# Altium schematic sheet Digital.SchDoc
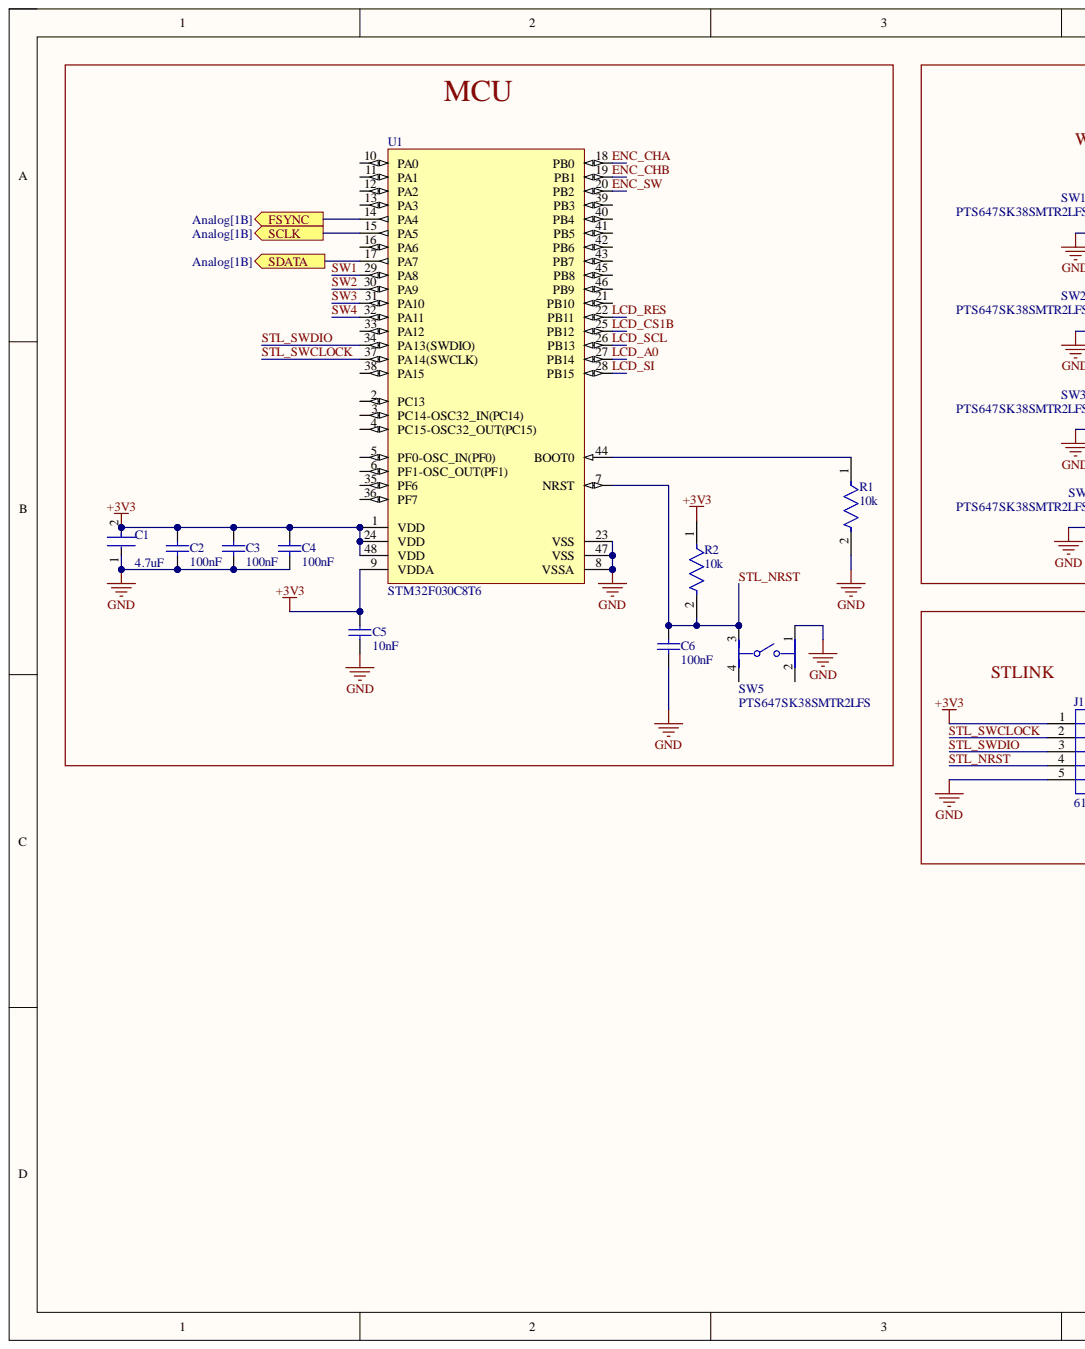
[diagram p0: Digital.SchDoc, left]
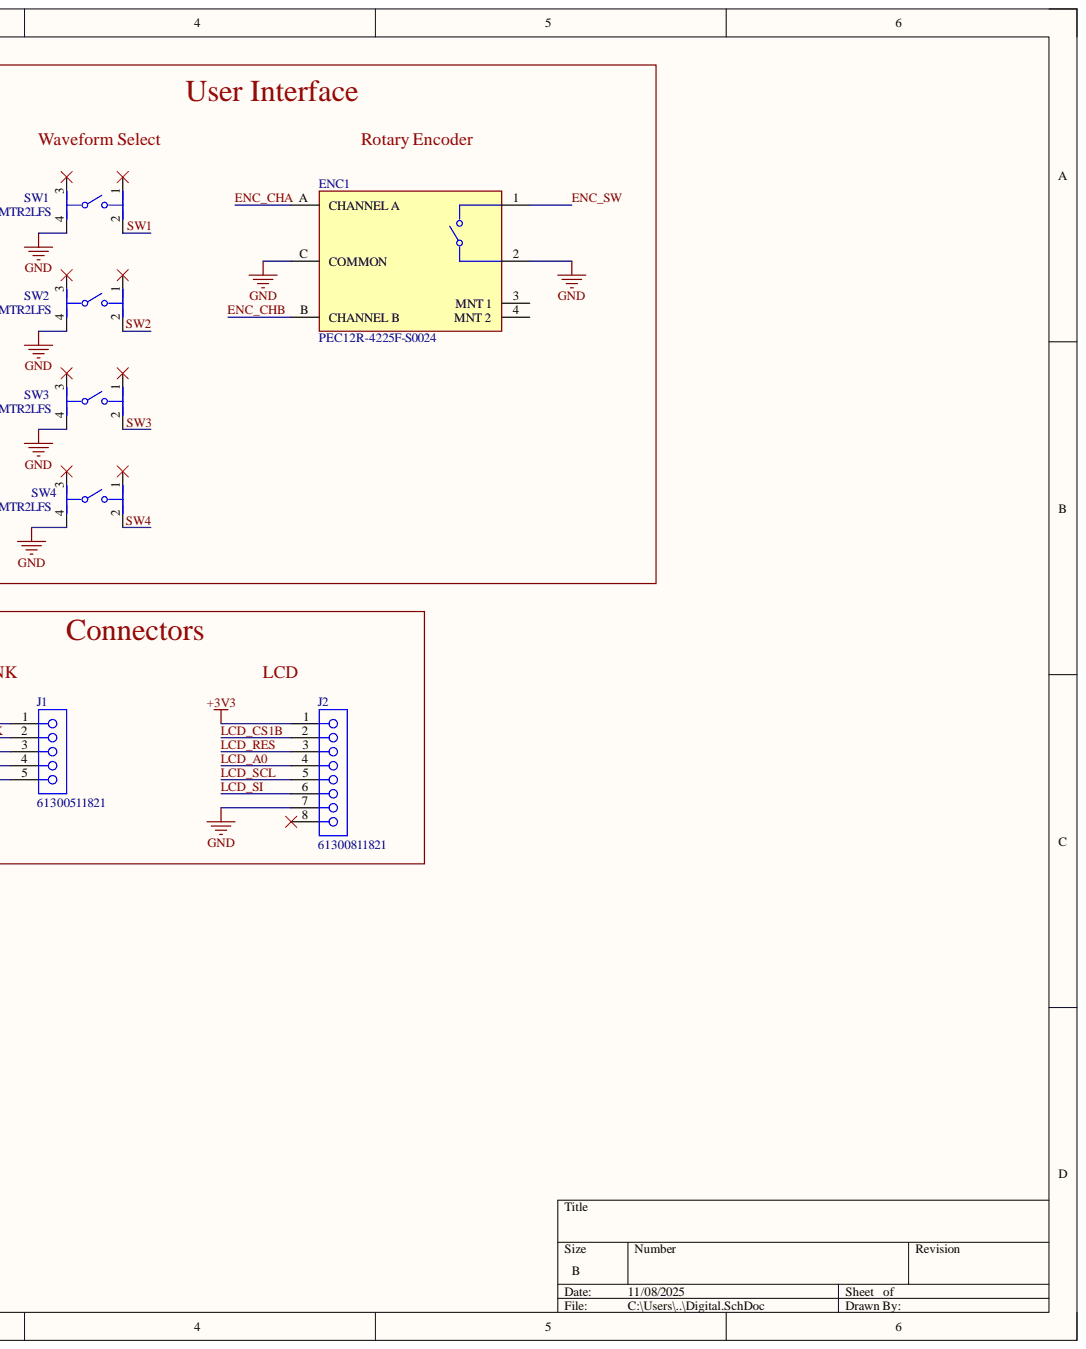
[diagram p1: Digital.SchDoc, right]

## Parts
C1  4.7uF  [FP-GRM219-0_15-0_1-IPC_B]
C2  100nF  [CAPC1608X87X45LL20T05]
C3  100nF  [CAPC1608X87X45LL20T05]
C4  100nF  [CAPC1608X87X45LL20T05]
C5  10nF  [CAPC1206(3216)150_L]
C6  100nF  [CAPC1608X87X45LL20T05]
ENC1  PEC12R-4225F-S0024  [FP-PEC12R-4225F-S0024-MFG]
J1  61300511821  [61300511821]
J2  61300811821  [61300811821]
R1  10k  [FP-TFCR0402-IPC_B]
R2  10k  [FP-TFCR0402-IPC_B]
SW1  PTS647SK38SMTR2LFS  [FP-PTS647SK38SMTR2LFS-MFG]
SW2  PTS647SK38SMTR2LFS  [FP-PTS647SK38SMTR2LFS-MFG]
SW3  PTS647SK38SMTR2LFS  [FP-PTS647SK38SMTR2LFS-MFG]
SW4  PTS647SK38SMTR2LFS  [FP-PTS647SK38SMTR2LFS-MFG]
SW5  PTS647SK38SMTR2LFS  [FP-PTS647SK38SMTR2LFS-MFG]
U1  STM32F030C8T6  [STM-LQFP48_M]

## Ports
FSYNC, SCLK, SDATA

## Nets
+3V3: C1.2 C2.2 C3.2 C4.2 C5.2 J1.1 J2.1 R2.1 U1.1(VDD) U1.9(VDDA) U1.24(VDD) U1.48(VDD)
GND: C1.1 C2.1 C3.1 C4.1 C5.1 C6.1 ENC1.2 ENC1.C(COMMON) J1.5 J2.7 R1.2 SW1.4 SW2.4 SW3.4 SW4.4 SW5.1 U1.8(VSSA) U1.23(VSS) U1.47(VSS)
ENC_CHA: ENC1.A(CHANNEL_A) U1.18(PB0)
ENC_CHB: ENC1.B(CHANNEL_B) U1.19(PB1)
ENC_SW: ENC1.1 U1.20(PB2)
FSYNC: U1.14(PA4) ; port FSYNC
LCD_A0: J2.4 U1.27(PB14)
LCD_CS1B: J2.2 U1.25(PB12)
LCD_RES: J2.3 U1.22(PB11)
LCD_SCL: J2.5 U1.26(PB13)
LCD_SI: J2.6 U1.28(PB15)
SCLK: U1.15(PA5) ; port SCLK
SDATA: U1.17(PA7) ; port SDATA
STL_NRST: C6.2 J1.4 R2.2 SW5.3 U1.7(NRST)
STL_SWCLOCK: J1.2 U1.37(PA14(SWCLK))
STL_SWDIO: J1.3 U1.34(PA13(SWDIO))
SW1: SW1.2 U1.29(PA8)
SW2: SW2.2 U1.30(PA9)
SW3: SW3.2 U1.31(PA10)
SW4: SW4.2 U1.32(PA11)
NetR1_1: R1.1 U1.44(BOOT0)

Not connected: ENC1 3(MNT_1) 4(MNT_2); J2 8; SW1 1 3; SW2 1 3; SW3 1 3; SW4 1 3; SW5 2 4; U1 2(PC13) 3(PC14-OSC32_IN(PC14)) 4(PC15-OSC32_OUT(PC15)) 5(PF0-OSC_IN(PF0)) 6(PF1-OSC_OUT(PF1)) 10(PA0) 11(PA1) 12(PA2) 13(PA3) 16(PA6) 21(PB10) 33(PA12) 35(PF6) 36(PF7) 38(PA15) 39(PB3) 40(PB4) 41(PB5) 42(PB6) 43(PB7) 45(PB8) 46(PB9)

## Library data of the parts
C1 = CMP-06035-028467-1: Chip Multilayer Ceramic Capacitors for General Purpose, 0805, 4.7uF, X5R, 15%, 20%, 25V; Component Kind: Standard; Library Name: Altium Content Vault
C2-C4 C6 = CMP-2006-00001-1: Ceramic 100nF X7R 16V 5%; Manufacturer: Kemet; Part Number: C0603X104J4RACTU; Component Kind: Standard; Library Name: Altium Content Vault
C5 = CMP-1037-03452-1: CAP 10nF 10V ±5% 1206 (3216 Metric) Thickness 1.45mm SMD; Case-EIA: 1206; Case-Metric: 3216; Tolerance: Â±5%; Component Kind: Standard; Library Name: Altium Content Vault; Max Thickness: 1.45 mm; Rated Voltage: 10 V; Technology: SMT; Value: 10nF
ENC1 = CMP-07421-000041-1: ROTARY ENCODER MECHANICAL 24PPR; Built in Switch: Yes; Hole Diameter: 1mm; Number of Channels: 2; Output Type: Quadrature; Pulses per Revolution: 24; Component Kind: Standard; Detent: Yes; Encoder Type: Mechanical; Height: 13.4mm; Length: 13.4mm; Library Name: Altium Content Vault; Max Operating Temperature: 70°C; Min Operating Temperature: -30°C; Mount: Through Hole; Orientation: Vertical; Package Quantity: 75; Packaging: Bulk; REACH SVHC: No SVHC; RoHS Compliant: Yes; Series: PEC12R; Termination: PC Pin; Width: 12.5mm
J1 = CMP-1502-01320-3: NexarPartId: 61924716; Contact Resistance: 20mΩ; Current Rating: 3A; Gender: Female; Operating Supply Voltage: 250V; Component Kind: Standard; Lead Pitch: 2.54mm; Library Name: Altium Content Vault; Number of Pins: 5; Orientation: Vertical; RoHS: Non-Compliant
J2 = CMP-1502-01327-3: NexarPartId: 61924723; Contact Resistance: 20mΩ; Current Rating: 3A; Gender: Female; Operating Supply Voltage: 250V; Component Kind: Standard; Lead Pitch: 2.54mm; Library Name: Altium Content Vault; Number of Pins: 8; Orientation: Vertical; RoHS: Non-Compliant
R1 R2 = CMP-250906-167145-1: RES Thin Film,  10kΩ, 0.1%, 0.063W, 50ppm/°C, 0402; Component Kind: Standard; Library Name: Altium Content Vault
SW1-SW5 = CMP-10040-000033-1: TACT 4.5 X 4.5, 3.8 MM H, 2.5N,; Actuator Type: Standard; Illumination Type: Non-Illuminated; Mechanical Life: 100000Cycles; Operating Force: 250gf; Ratings: IP40; Switch Function: Off-Mom; Switch Travel: 0.25mm; Throw Configuration: SPST; Component Kind: Standard; Library Name: Altium Content Vault; Max Operating Temperature: 70°C; Min Operating Temperature: -20°C; Mount: Surface Mount; Packaging: Tape and Reel; Termination: Gull Wing
U1 = CMP-0304-00064-2: ARM Cortex-M0 32-bit MCU, 64 KB Flash, 8 KB Internal RAM, 39 I/Os, 48-Pin LQFP, -40 to 85 degC, Tape and Reel; Manufacturer: STMicroelectronics; PartNumber: STM32F030C8T6TR; FLASH Size (Prog) (kB): 64; I/Os (High Current): 39; Internal RAM Size (kB): 8; Operating Frequency(F) (Processor speed) (MHz): 48; Supply Voltage(Vcc) min (V): 2.4; Component Kind: Standard; ComponentLink1Description: Manufacturer URL; ComponentLink1URL: http://www.st.com/; ComponentLink2Description: Datasheet; ComponentLink2URL: http://www.st.com/st-web-ui/static/active/en/resource/technical/document/datasheet/DM00088500.pdf; Core: ARM Cortex-M0; DatasheetVersion: Rev. 2; Library Name: Altium Content Vault; Mounting Technology: Surface Mount; Package Description: 48-Pin Low Profile Quad Flat Package, Body 7 x 7 mm, Pitch 0.5 mm; Package Reference: LQFP48; Packing: Tape and Reel
